# Altium schematic sheet Page 4.SchDoc("Page 4")
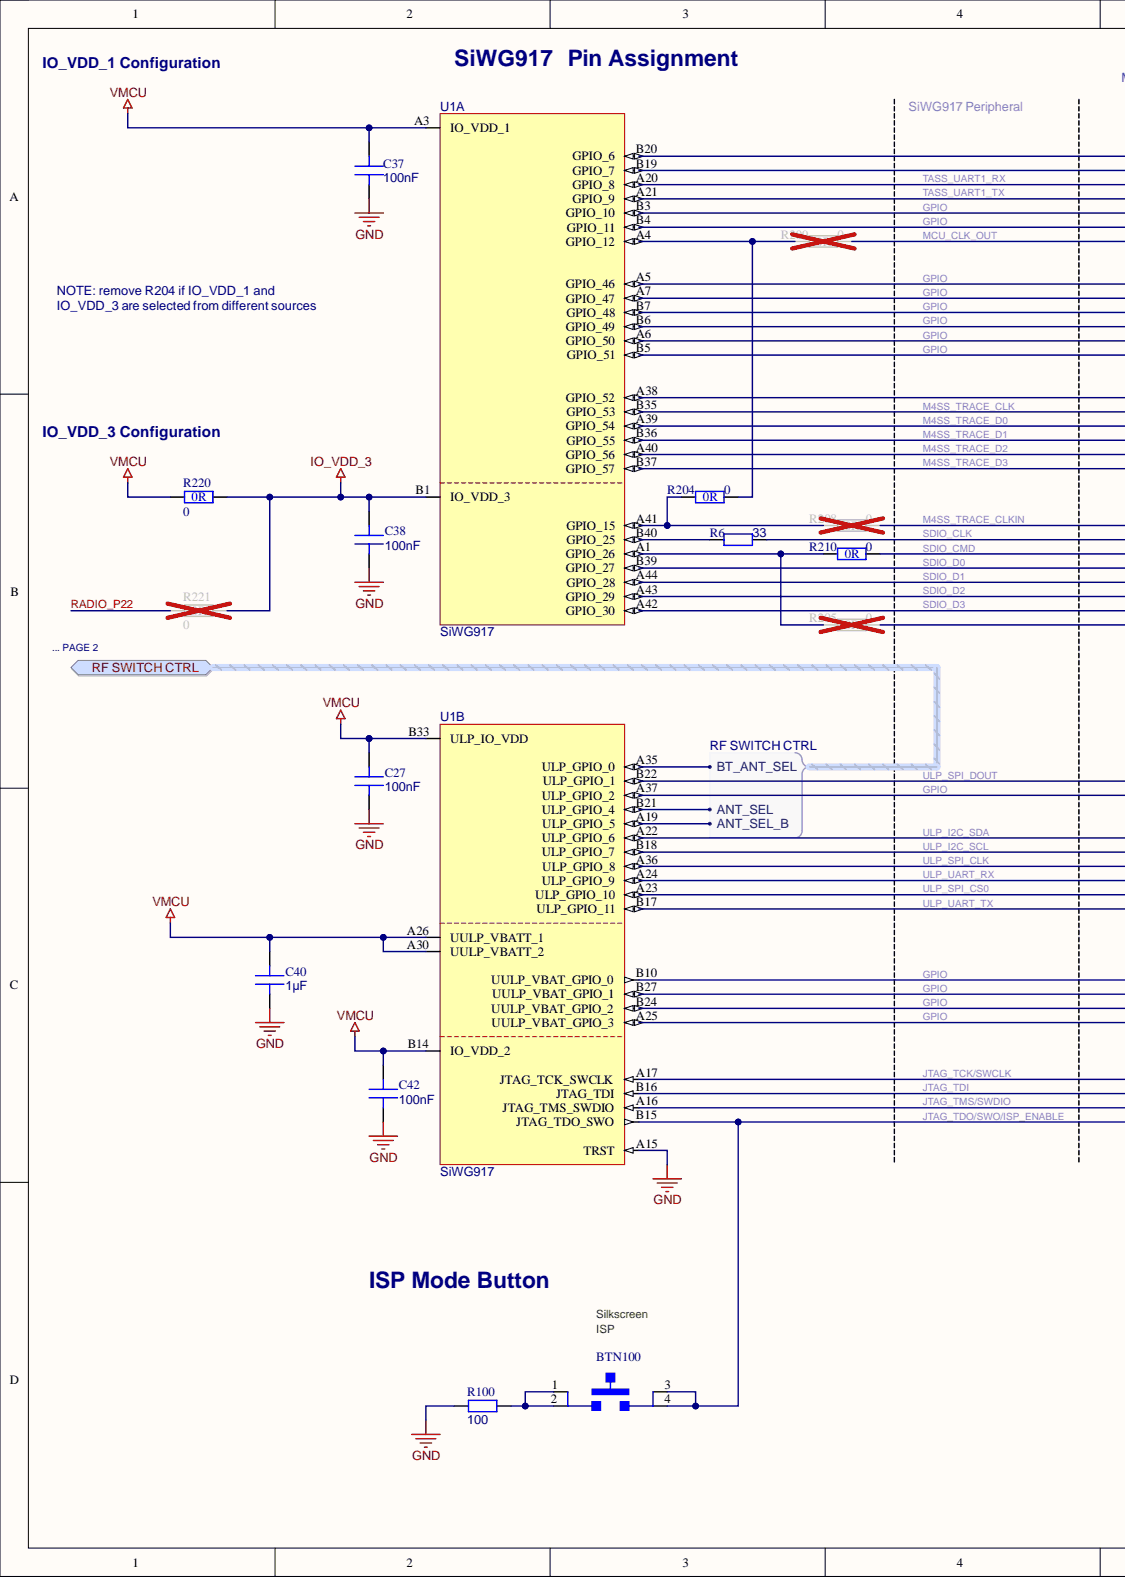
[diagram p0: Page 4.SchDoc, left]
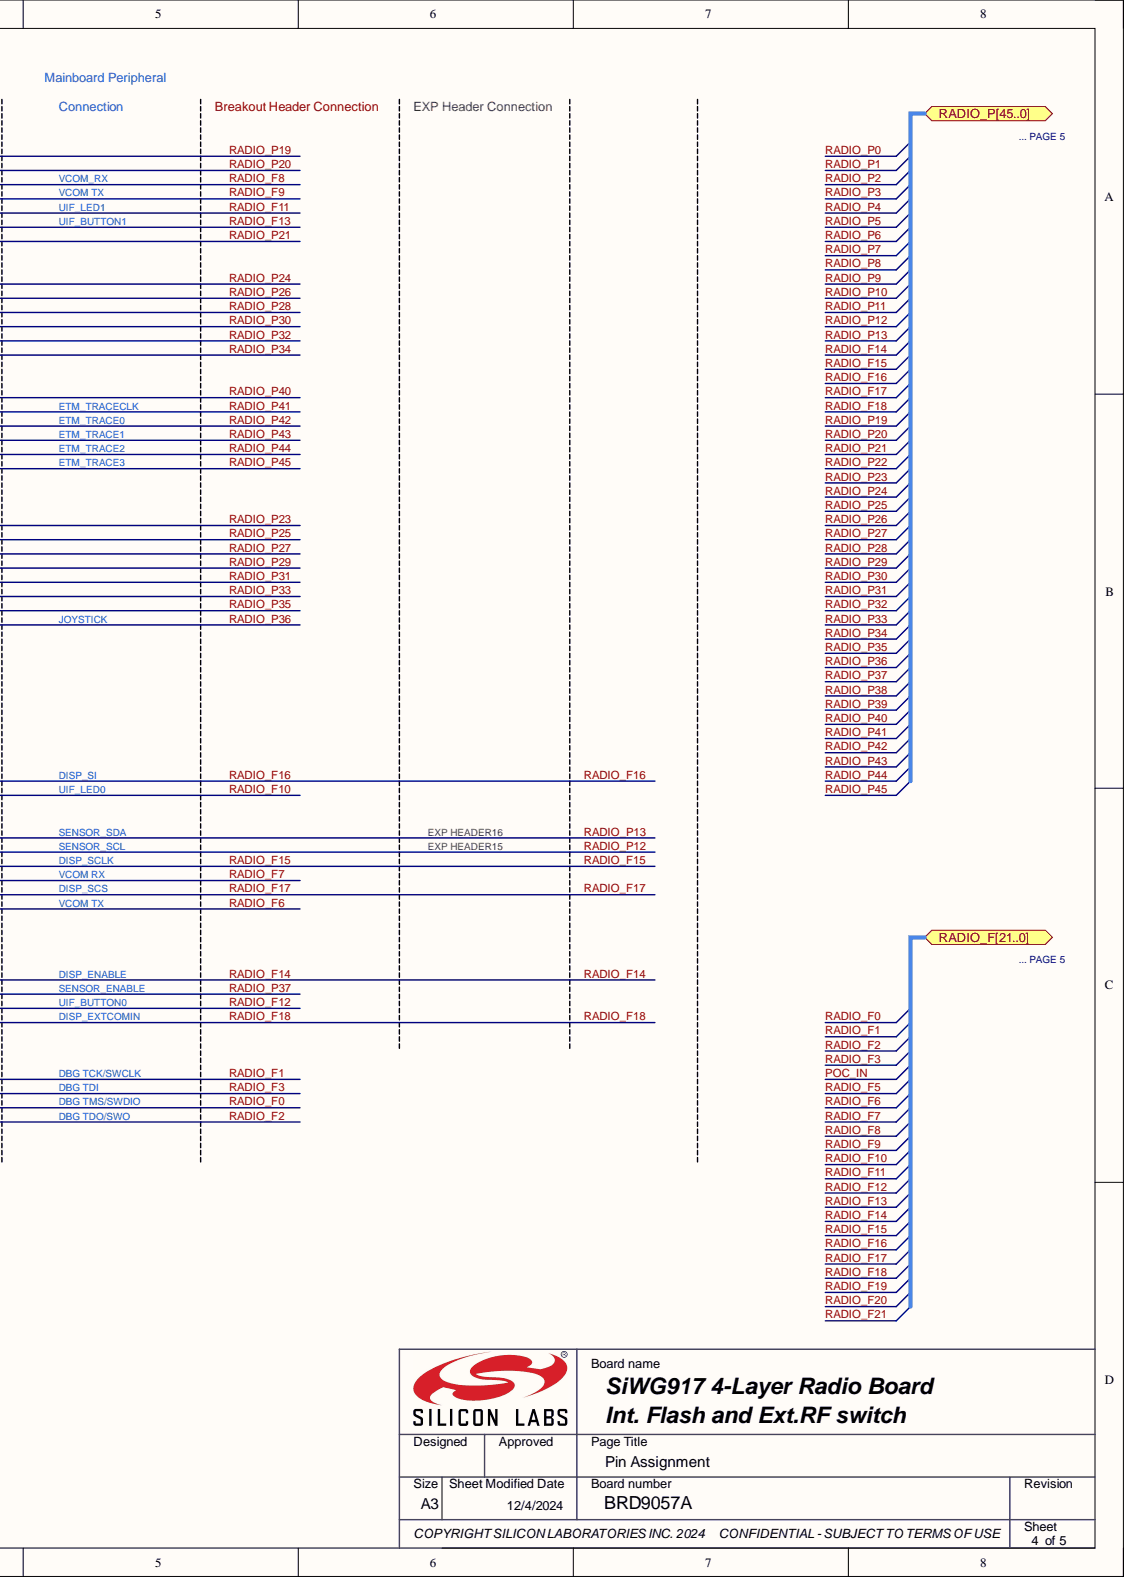
[diagram p1: Page 4.SchDoc, right]

## Parts
BTN100  PTS810 SJM 250 SMTR LFS  [SW_PTS810SJM250SMTRLFS]  (value not drawn)
C27  value 100nF  [C0201_GM]  (hidden comment: CAP CER 0201 X5R 100nF 16V 10%)
C37  value 100nF  [C0201_GM]  (hidden comment: CAP CER 0201 X5R 100nF 16V 10%)
C38  value 100nF  [C0201_GM]  (hidden comment: CAP CER 0201 X5R 100nF 16V 10%)
C40  value 1µF  [C0201_GM]  (hidden comment: CAP CER 0201 X5R 1uF 16V 20%)
C42  value 100nF  [C0201_GM]  (hidden comment: CAP CER 0201 X5R 100nF 16V 10%)
R6  value 33  [R0201]  (hidden comment: RES 0201 33R 1/20W 1% 200ppm)
R100  value 100  [R0201]  (hidden comment: RES 0201 100R 1/20W 1% 200ppm)
R204  value 0  [R0402]  (hidden comment: RES 0402 0R)
R205  value 0  [R0402]  (hidden comment: RES 0402 0R)  (no BOM)
R208  value 0  [R0402]  (hidden comment: RES 0402 0R)  (no BOM)
R209  value 0  [R0402]  (hidden comment: RES 0402 0R)  (no BOM)
R210  value 0  [R0402]  (hidden comment: RES 0402 0R)
R220  value 0  [R0402]  (hidden comment: RES 0402 0R)
R221  value 0  [R0402]  (hidden comment: RES 0402 0R)  (no BOM)
U1  value SiWG917  [QFN84_SI_SiWx917_A1]  (hidden comment: SiWG917M111MGTBAR)  (parts A,B)

## Ports
RADIO_F0, RADIO_F1, RADIO_F2, RADIO_F3, RADIO_F4, RADIO_F5, RADIO_F6, RADIO_F7, RADIO_F8, RADIO_F9, RADIO_F10, RADIO_F11, RADIO_F12, RADIO_F13, RADIO_F14, RADIO_F15, RADIO_F16, RADIO_F17, RADIO_F18, RADIO_F19, RADIO_F20, RADIO_F21, RADIO_F[21..0], RADIO_P0, RADIO_P1, RADIO_P2, RADIO_P3, RADIO_P4, RADIO_P5, RADIO_P6, RADIO_P7, RADIO_P8, RADIO_P9, RADIO_P10, RADIO_P11, RADIO_P12, RADIO_P13, RADIO_P14, RADIO_P15, RADIO_P16, RADIO_P17, RADIO_P18, RADIO_P19, RADIO_P20, RADIO_P21, RADIO_P22, RADIO_P23, RADIO_P24, RADIO_P25, RADIO_P26, RADIO_P27, RADIO_P28, RADIO_P29, RADIO_P30, RADIO_P31, RADIO_P32, RADIO_P33, RADIO_P34, RADIO_P35, RADIO_P36, RADIO_P37, RADIO_P38, RADIO_P39, RADIO_P40, RADIO_P41, RADIO_P42, RADIO_P43, RADIO_P44, RADIO_P45, RADIO_P[45..0], RF SWITCH CTRL, RF SWITCH CTRL.ANT_SEL, RF SWITCH CTRL.ANT_SEL_B, RF SWITCH CTRL.BT_ANT_SEL

## Nets
GND: C27.1 C37.1 C38.1 C40.1 C42.1 R100.2 U1.A15(TRST)
IO_VDD_3: C38.2 R220.2 R221.2 U1.B1(IO_VDD_3)
VMCU: C27.2 C37.2 C40.2 C42.2 R220.1 U1.A3(IO_VDD_1) U1.A26(UULP_VBATT_1) U1.A30(UULP_VBATT_2) U1.B14(IO_VDD_2) U1.B33(ULP_IO_VDD)
RADIO_F0: U1.A16(JTAG_TMS_SWDIO) ; port RADIO_F[21..0]
RADIO_F1: U1.A17(JTAG_TCK_SWCLK) ; port RADIO_F[21..0]
RADIO_F2: BTN100.3 BTN100.4 U1.B15(JTAG_TDO_SWO) ; port RADIO_F[21..0]
RADIO_F3: U1.B16(JTAG_TDI) ; port RADIO_F[21..0]
RADIO_F6: U1.B17(ULP_GPIO_11) ; port RADIO_F[21..0]
RADIO_F7: U1.A24(ULP_GPIO_9) ; port RADIO_F[21..0]
RADIO_F8: U1.A20(GPIO_8) ; port RADIO_F[21..0]
RADIO_F9: U1.A21(GPIO_9) ; port RADIO_F[21..0]
RADIO_F10: U1.A37(ULP_GPIO_2) ; port RADIO_F[21..0]
RADIO_F11: U1.B3(GPIO_10) ; port RADIO_F[21..0]
RADIO_F12: U1.B24(UULP_VBAT_GPIO_2) ; port RADIO_F[21..0]
RADIO_F13: U1.B4(GPIO_11) ; port RADIO_F[21..0]
RADIO_F14: U1.B10(UULP_VBAT_GPIO_0) ; port RADIO_F[21..0], port RADIO_P[45..0]
RADIO_F14: U1.B10(UULP_VBAT_GPIO_0) ; port RADIO_F[21..0], port RADIO_P[45..0]
RADIO_F15: U1.A36(ULP_GPIO_8) ; port RADIO_F[21..0], port RADIO_P[45..0]
RADIO_F15: U1.A36(ULP_GPIO_8) ; port RADIO_F[21..0], port RADIO_P[45..0]
RADIO_F16: U1.B22(ULP_GPIO_1) ; port RADIO_F[21..0], port RADIO_P[45..0]
RADIO_F16: U1.B22(ULP_GPIO_1) ; port RADIO_F[21..0], port RADIO_P[45..0]
RADIO_F17: U1.A23(ULP_GPIO_10) ; port RADIO_F[21..0], port RADIO_P[45..0]
RADIO_F17: U1.A23(ULP_GPIO_10) ; port RADIO_F[21..0], port RADIO_P[45..0]
RADIO_F18: U1.A25(UULP_VBAT_GPIO_3) ; port RADIO_F[21..0], port RADIO_P[45..0]
RADIO_F18: U1.A25(UULP_VBAT_GPIO_3) ; port RADIO_F[21..0], port RADIO_P[45..0]
RADIO_P12: U1.B18(ULP_GPIO_7) ; port RADIO_P[45..0]
RADIO_P13: U1.A22(ULP_GPIO_6) ; port RADIO_P[45..0]
RADIO_P19: U1.B20(GPIO_6) ; port RADIO_P[45..0]
RADIO_P20: U1.B19(GPIO_7) ; port RADIO_P[45..0]
RADIO_P21: R209.2 ; port RADIO_P[45..0]
RADIO_P22: R221.1 ; port RADIO_P[45..0]
RADIO_P23: R208.2 ; port RADIO_P[45..0]
RADIO_P24: U1.A5(GPIO_46) ; port RADIO_P[45..0]
RADIO_P25: R6.2 ; port RADIO_P[45..0]
RADIO_P26: U1.A7(GPIO_47) ; port RADIO_P[45..0]
RADIO_P27: R210.2 ; port RADIO_P[45..0]
RADIO_P28: U1.B7(GPIO_48) ; port RADIO_P[45..0]
RADIO_P29: U1.B39(GPIO_27) ; port RADIO_P[45..0]
RADIO_P30: U1.B6(GPIO_49) ; port RADIO_P[45..0]
RADIO_P31: U1.A44(GPIO_28) ; port RADIO_P[45..0]
RADIO_P32: U1.A6(GPIO_50) ; port RADIO_P[45..0]
RADIO_P33: U1.A43(GPIO_29) ; port RADIO_P[45..0]
RADIO_P34: U1.B5(GPIO_51) ; port RADIO_P[45..0]
RADIO_P35: U1.A42(GPIO_30) ; port RADIO_P[45..0]
RADIO_P36: R205.2 ; port RADIO_P[45..0]
RADIO_P37: U1.B27(UULP_VBAT_GPIO_1) ; port RADIO_P[45..0]
RADIO_P40: U1.A38(GPIO_52) ; port RADIO_P[45..0]
RADIO_P41: U1.B35(GPIO_53) ; port RADIO_P[45..0]
RADIO_P42: U1.A39(GPIO_54) ; port RADIO_P[45..0]
RADIO_P43: U1.B36(GPIO_55) ; port RADIO_P[45..0]
RADIO_P44: U1.A40(GPIO_56) ; port RADIO_P[45..0]
RADIO_P45: U1.B37(GPIO_57) ; port RADIO_P[45..0]
NetBTN100_1: BTN100.1 BTN100.2 R100.1
NetR6_1: R6.1 U1.B40(GPIO_25)
NetR204_1: R204.1 R208.1 U1.A41(GPIO_15)
NetR204_2: R204.2 R209.1 U1.A4(GPIO_12)
NetR205_1: R205.1 R210.1 U1.A1(GPIO_26)
NetU1_A19: U1.A19(ULP_GPIO_5) ; port RF SWITCH CTRL
NetU1_A35: U1.A35(ULP_GPIO_0) ; port RF SWITCH CTRL
NetU1_B21: U1.B21(ULP_GPIO_4) ; port RF SWITCH CTRL
RADIO_F4: ; port RADIO_F[21..0]
RADIO_F5: ; port RADIO_F[21..0]
RADIO_F19: ; port RADIO_F[21..0]
RADIO_F20: ; port RADIO_F[21..0]
RADIO_F21: ; port RADIO_F[21..0]
RADIO_P0: ; port RADIO_P[45..0]
RADIO_P1: ; port RADIO_P[45..0]
RADIO_P2: ; port RADIO_P[45..0]
RADIO_P3: ; port RADIO_P[45..0]
RADIO_P4: ; port RADIO_P[45..0]
RADIO_P5: ; port RADIO_P[45..0]
RADIO_P6: ; port RADIO_P[45..0]
RADIO_P7: ; port RADIO_P[45..0]
RADIO_P8: ; port RADIO_P[45..0]
RADIO_P9: ; port RADIO_P[45..0]
RADIO_P10: ; port RADIO_P[45..0]
RADIO_P11: ; port RADIO_P[45..0]
RADIO_P38: ; port RADIO_P[45..0]
RADIO_P39: ; port RADIO_P[45..0]

## Library data of the parts
BTN100 = CMP-185-00008-A.03: Tactile Switch SPST-NO 0.05A 16V; Manufacturer: C&K; Component Kind: Standard; Library Name: SiLabs Production Server; Manufacturer PN: PTS810 SJM 250 SMTR LFS; Max Operating Temp: 85°C; Min Operating Temp: -40°C; Mount: SMD; Package: 4.2mm X 3.2mm; RoHS: Compliant; SubCategory Tag: Tactile; Value: PTS810
C27 C37 C38 C42 = CMP-120-00007-B.06: SubCategory Tag: Ceramic; Component Kind: Standard; Description: CAP CER 0201 X5R 100nF 16V 10%; Library Name: SiLabs Production Server; Material: X5R; Max Operating Temp: 85°C; Min Operating Temp: -55°C; Mount: SMD; Package: 0201; Rating: 16V; RoHS: Compliant; Tolerance: 10%
C40 = CMP-120-00154-A.04: SubCategory Tag: Ceramic; Component Kind: Standard; Description: CAP CER 0201 X5R 1uF 16V 20%; Library Name: SiLabs Production Server; Material: X5R; Max Operating Temp: 85°C; Min Operating Temp: -55°C; Mount: SMD; Package: 0201; Rating: 16V; RoHS: Compliant; Tolerance: 20%
R6 = CMP-180-00277-A.01: Rating: 50mW; SubCategory Tag: Thick Film; Component Kind: Standard; Description: RES 0201 33R 1/20W 1% 200ppm; Library Name: SiLabs Production Server; Max Operating Temp: 155°C; Min Operating Temp: -55°C; Mount: SMD; Package: 0201; RoHS: Compliant; Tolerance: 1%
R100 = CMP-180-00121-A.01: Rating: 50mW; SubCategory Tag: Thick Film; Component Kind: Standard; Description: RES 0201 100R 1/20W 1% 200ppm; Library Name: SiLabs Production Server; Max Operating Temp: 155°C; Min Operating Temp: -55°C; Mount: SMD; Package: 0201; RoHS: Compliant; Tolerance: 1%
R204 R205 R208-R210 R220 R221 = CMP-180-00061-B.02: Rating: 62.5mW; SubCategory Tag: Thick Film; Tolerance: 0%; Description: RES 0402 0R; Library Name: SiLabs Production Server; Max Operating Temp: 155°C; Min Operating Temp: -55°C; Mount: SMD; Package: 0402; RoHS: Compliant
  R204 R210 R220: Component Kind: Standard
U1 = CMP-150-00167-C.02: IC SoC 32BIT 8MB FLASH QFN84 2.4GHz Single Band Wi-Fi; Manufacturer: Silicon Labs; Additional Search Tag: SoC Wireless; SubCategory Tag: Embedded; Voltage: 3.63V; Component Kind: Standard; Library Name: SiLabs Production Server; Manufacturer PN: SiWG917M111MGTBAR; Max Operating Temp: 85°C; Min Operating Temp: -40°C; Mount: SMD; Package: QFN84; RoHS: Compliant
